# Altium schematic sheet Headers.SchDoc
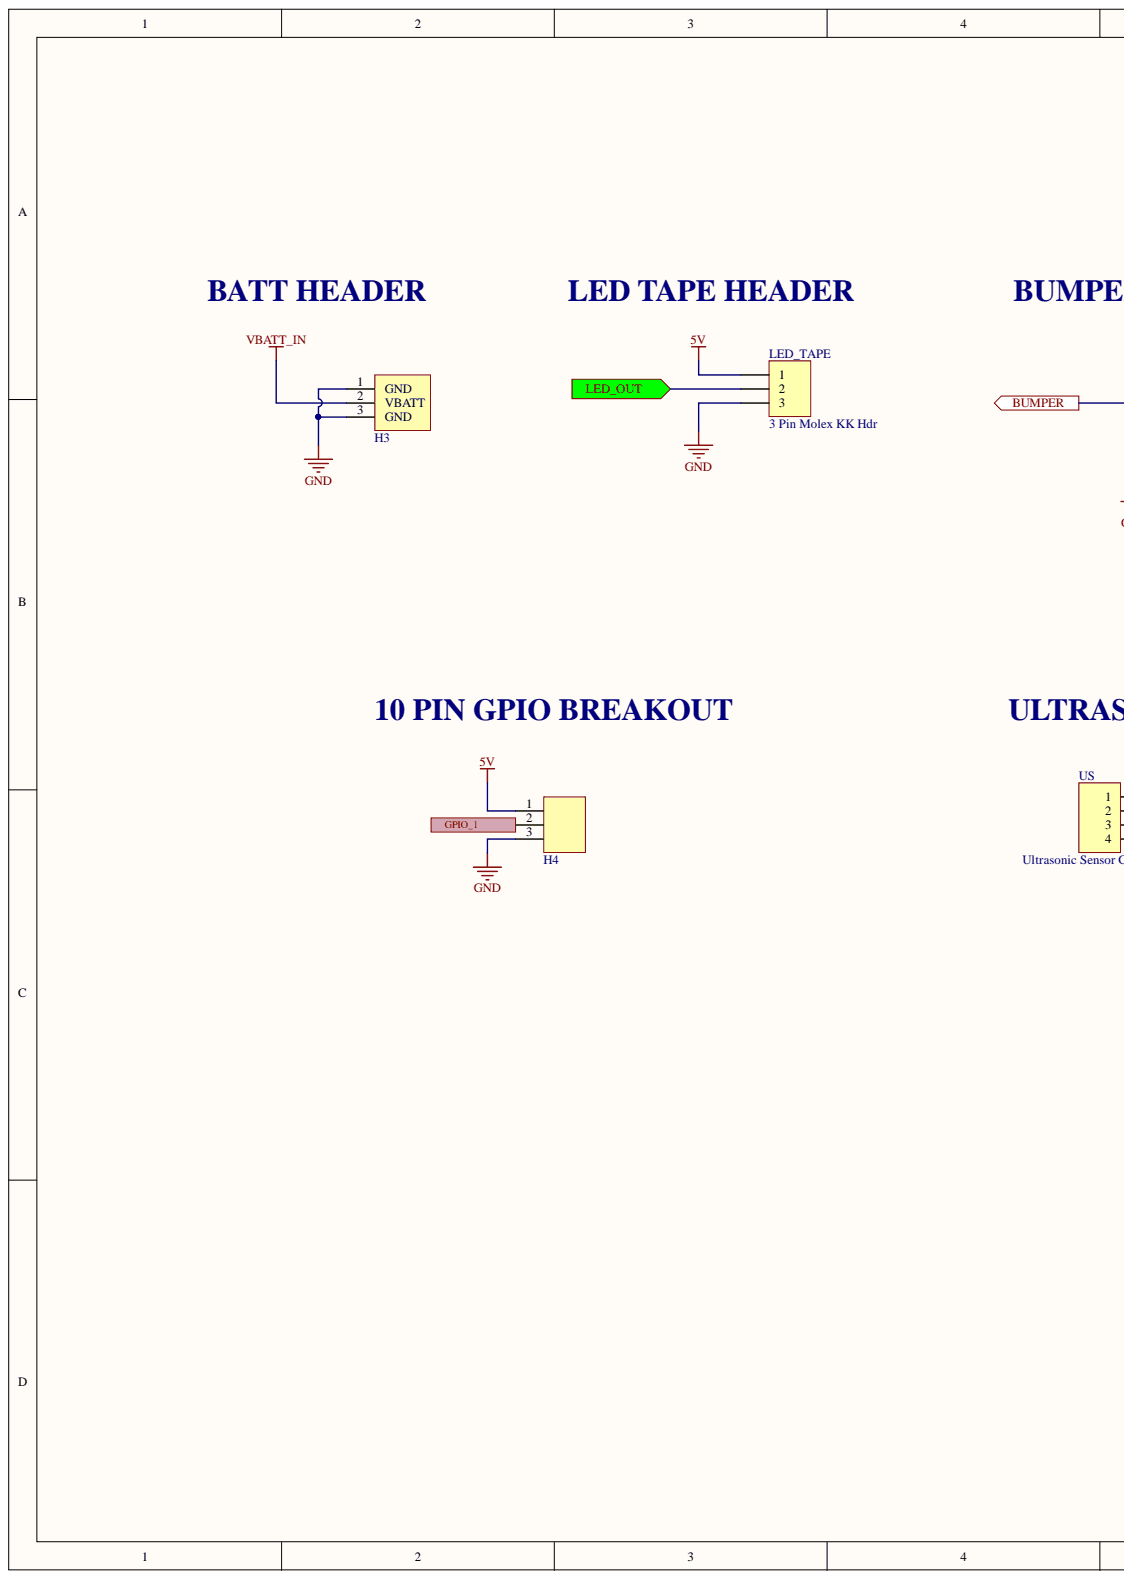
[diagram p0: Headers.SchDoc, left]
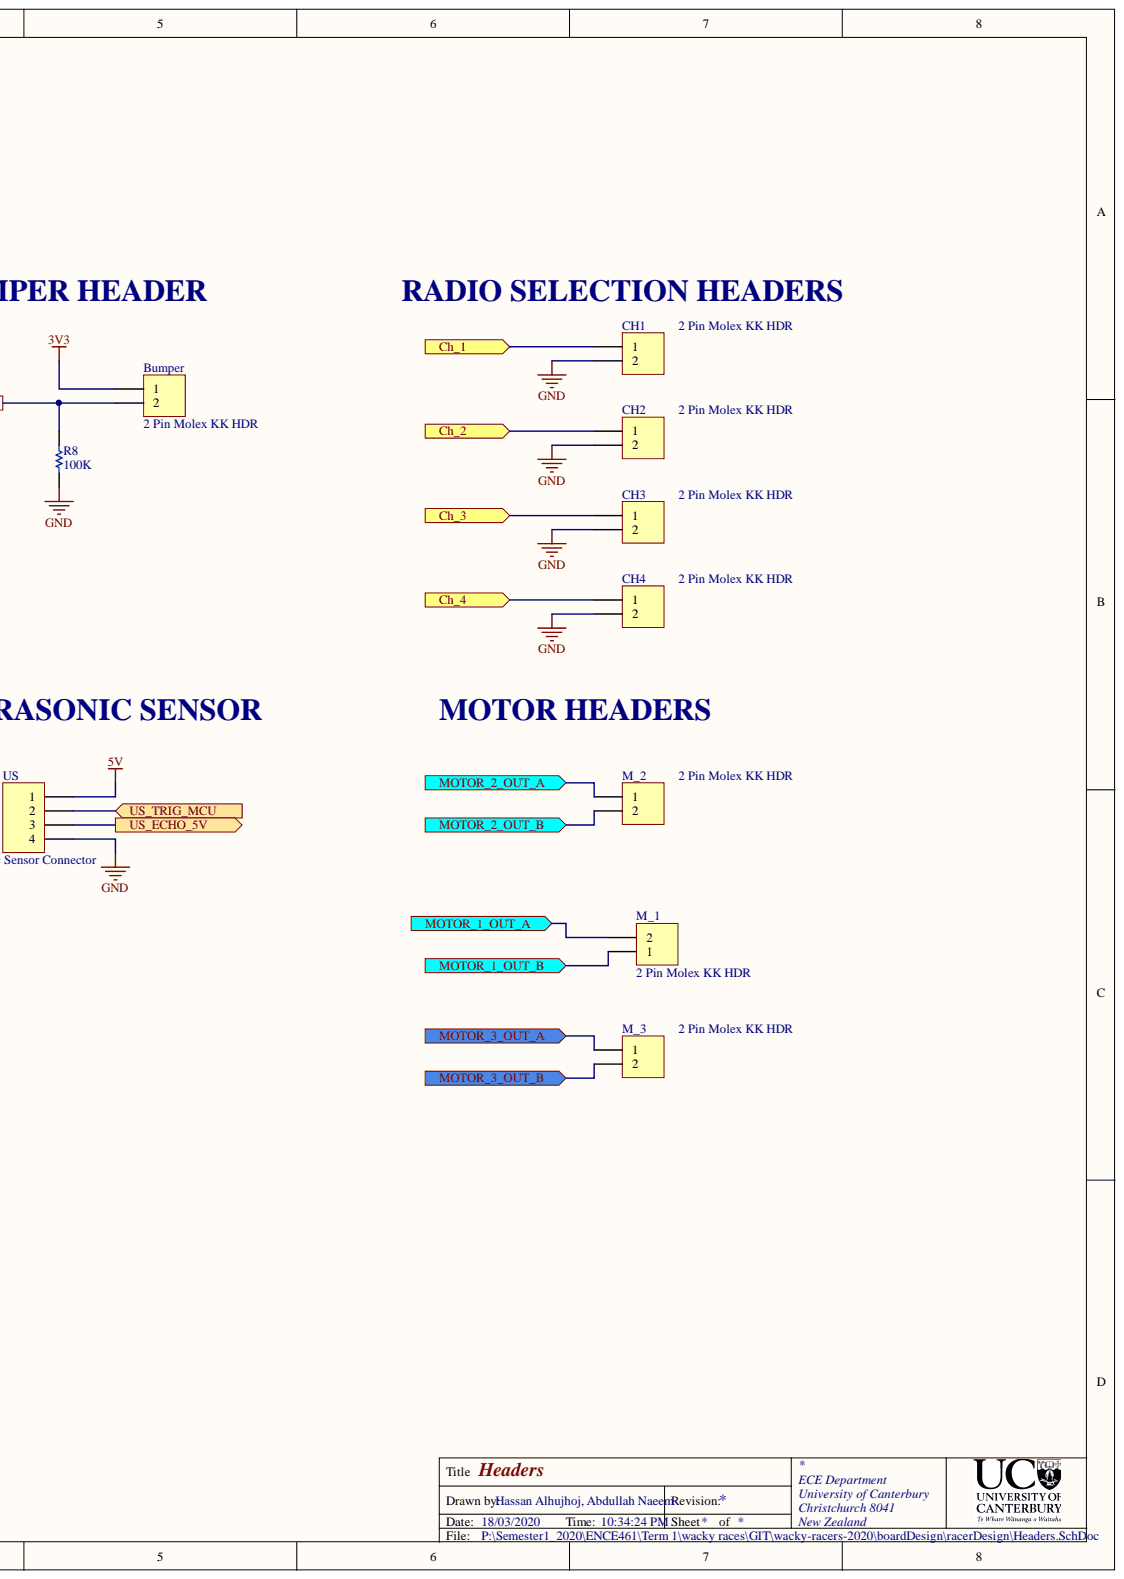
[diagram p1: Headers.SchDoc, right]

## Parts
Bumper  2 Pin Molex KK HDR  [MOLEX_KK_2_HDR]
CH1  2 Pin Molex KK HDR  [MOLEX_KK_2_HDR]
CH2  2 Pin Molex KK HDR  [MOLEX_KK_2_HDR]
CH3  2 Pin Molex KK HDR  [MOLEX_KK_2_HDR]
CH4  2 Pin Molex KK HDR  [MOLEX_KK_2_HDR]
H3  Battery_HEADER_3pin  [BATT_HEADER_3POS]  (value not drawn)
H4  HEADER_3  [HEADER_3POS]  (value not drawn)
LED_TAPE  3 Pin Molex KK Hdr  Manufacturer: Molex  [MOLEX_KK_3_HDR]
M_1  2 Pin Molex KK HDR  [MOLEX_KK_2_HDR]
M_2  2 Pin Molex KK HDR  [MOLEX_KK_2_HDR]
M_3  2 Pin Molex KK HDR  [MOLEX_KK_2_HDR]
R8  100K  [RESC1608L]
US  Ultrasonic Sensor Connector  [MOLEX_KK_4_HDR]

## Ports
BUMPER, Ch_1, Ch_2, Ch_3, Ch_4, GPIO_1, LED_OUT, MOTOR_1_OUT_A, MOTOR_1_OUT_B, MOTOR_2_OUT_A, MOTOR_2_OUT_B, MOTOR_3_OUT_A, MOTOR_3_OUT_B, US_ECHO_5V, US_TRIG_MCU

## Nets
3V3: Bumper.1
5V: H4.1 LED_TAPE.1 US.1
GND: CH1.2 CH2.2 CH3.2 CH4.2 H3.1 H3.3 H4.3 LED_TAPE.3 R8.1 US.4
VBATT_IN: H3.2
BUMPER: Bumper.2 R8.2 ; port BUMPER
Ch_1: CH1.1 ; port Ch_1
Ch_2: CH2.1 ; port Ch_2
Ch_3: CH3.1 ; port Ch_3
Ch_4: CH4.1 ; port Ch_4
GPIO_1: H4.2 ; port GPIO_1
LED_OUT: LED_TAPE.2 ; port LED_OUT
MOTOR_1_OUT_A: M_1.2 ; port MOTOR_1_OUT_A
MOTOR_1_OUT_B: M_1.1 ; port MOTOR_1_OUT_B
MOTOR_2_OUT_A: M_2.1 ; port MOTOR_2_OUT_A
MOTOR_2_OUT_B: M_2.2 ; port MOTOR_2_OUT_B
MOTOR_3_OUT_A: M_3.1 ; port MOTOR_3_OUT_A
MOTOR_3_OUT_B: M_3.2 ; port MOTOR_3_OUT_B
US_ECHO_5V: US.3 ; port US_ECHO_5V
US_TRIG_MCU: US.2 ; port US_TRIG_MCU

## Library data of the parts
Bumper CH1-CH4 M_1-M_3 = JA_MOLEX_KK_2POS: CONN HEADER 2POS 2.54mm VERT_Thru Hole; Component Kind: Standard; Library Name: ECE AD19Components_19V1.IntLib; Signal Integrity: Connector; Storage Location: ECE Dept Store; Supplier: ECE Dept; Supplier 1: Farnell; Supplier Part Number 1: 1462926; Value: 2 Pin Molex KK HDR
H3 = Battery_HEADER_3pin: 3Pin Header 2.54mm spacing; Component Kind: Standard; Library Name: ENCE461AD19_19V2.IntLib
H4 = HEADER_3: 3Pin Header 2.54mm spacing; Component Kind: Standard; Library Name: ENCE461AD19_19V2.IntLib
LED_TAPE = JA_MOLEX_KK_3POS: CONN HEADER 3POS 2.54mm VERT_Thru Hole; Component Kind: Standard; Library Name: ECE AD19Components_19V1.IntLib; Manufacturer PN: 22-23-2031; Signal Integrity: Connector; Storage Location: ECE Dept Store; Supplier: ECE Dept; Supplier 1: Farnell; Supplier Part Number 1: 9731156; Value: 3 Pin Molex KK Hdr
R8 = RS_100K: RES 100K OHM 1/10W 5% 0603 SMD; Component Kind: Standard; Library Name: ECE AD19Components_19V1.IntLib; Signal Integrity: Res; Simulation: RESISTOR; Storage Location: PCB Assembly WS; Supplier: ECE Dept; Value: 100K
US = JA_MOLEX_KK_4POS: CONN HEADER 4POS 2.54mm VERT_Thru Hole; Manufacturer: Molex; Component Kind: Standard; Library Name: ECE AD19Components_19V1.IntLib; Manufacturer PN: 22-23-2041; Signal Integrity: Connector; Storage Location: ECE Dept Store; Supplier: ECE Dept; Supplier 1: Farnell; Supplier Part Number 1: 9731164; Value: Ultrasonic Sensor Connector
